# Altium schematic sheet WLED_Pixie_Rev_A_3_CPU.SchDoc(WLED_Pixie_Rev_A_3_CPU)
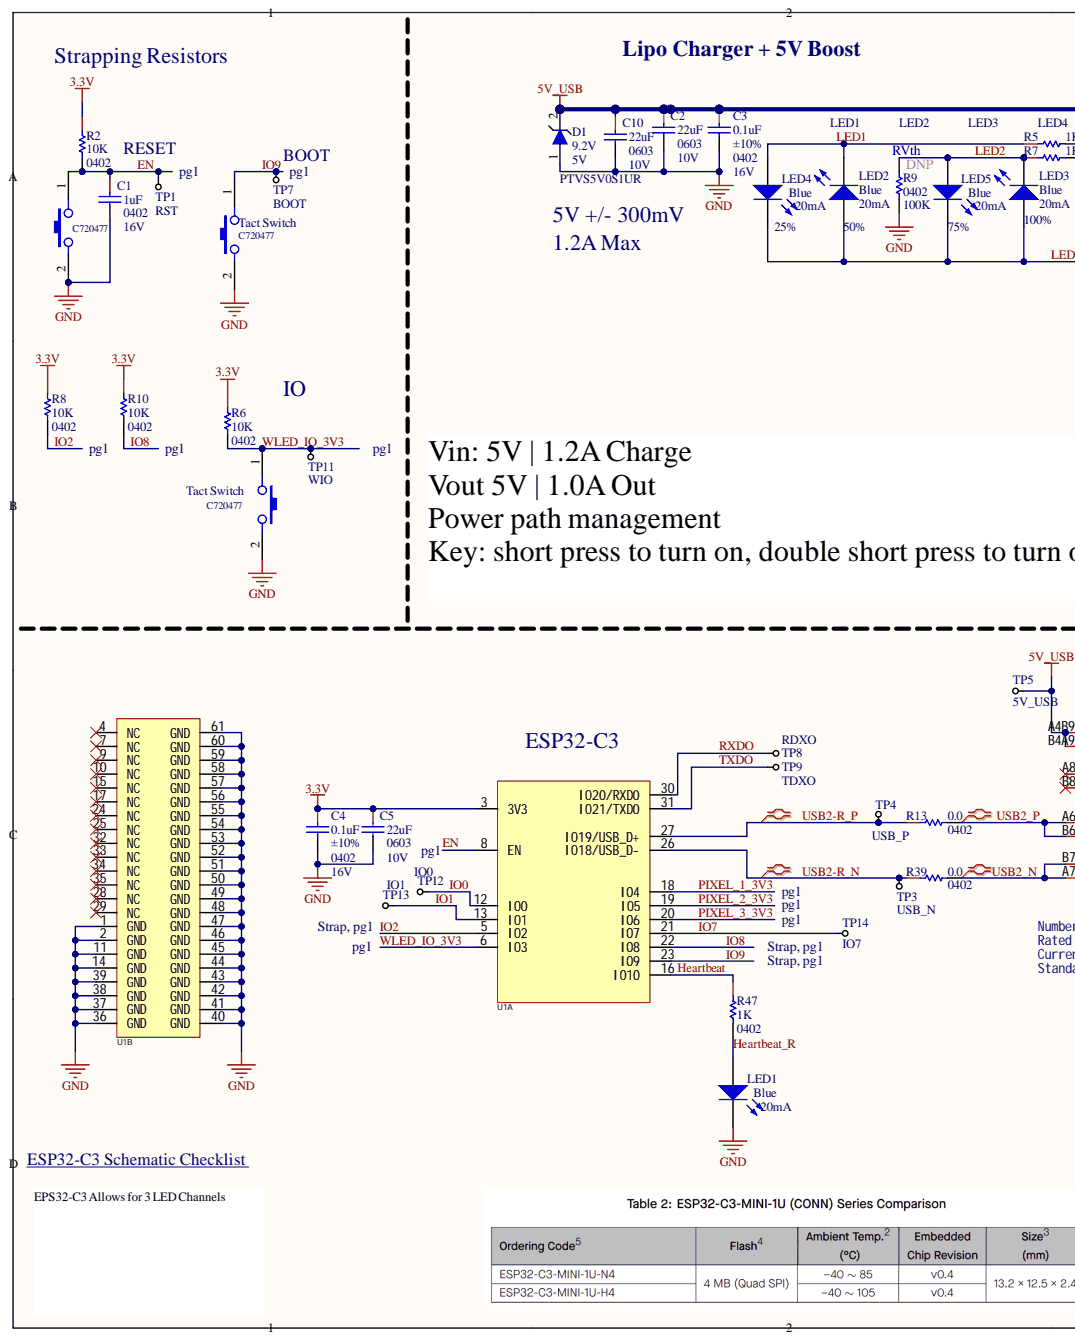
[diagram p0: WLED_Pixie_Rev_A_3_CPU.SchDoc, left]
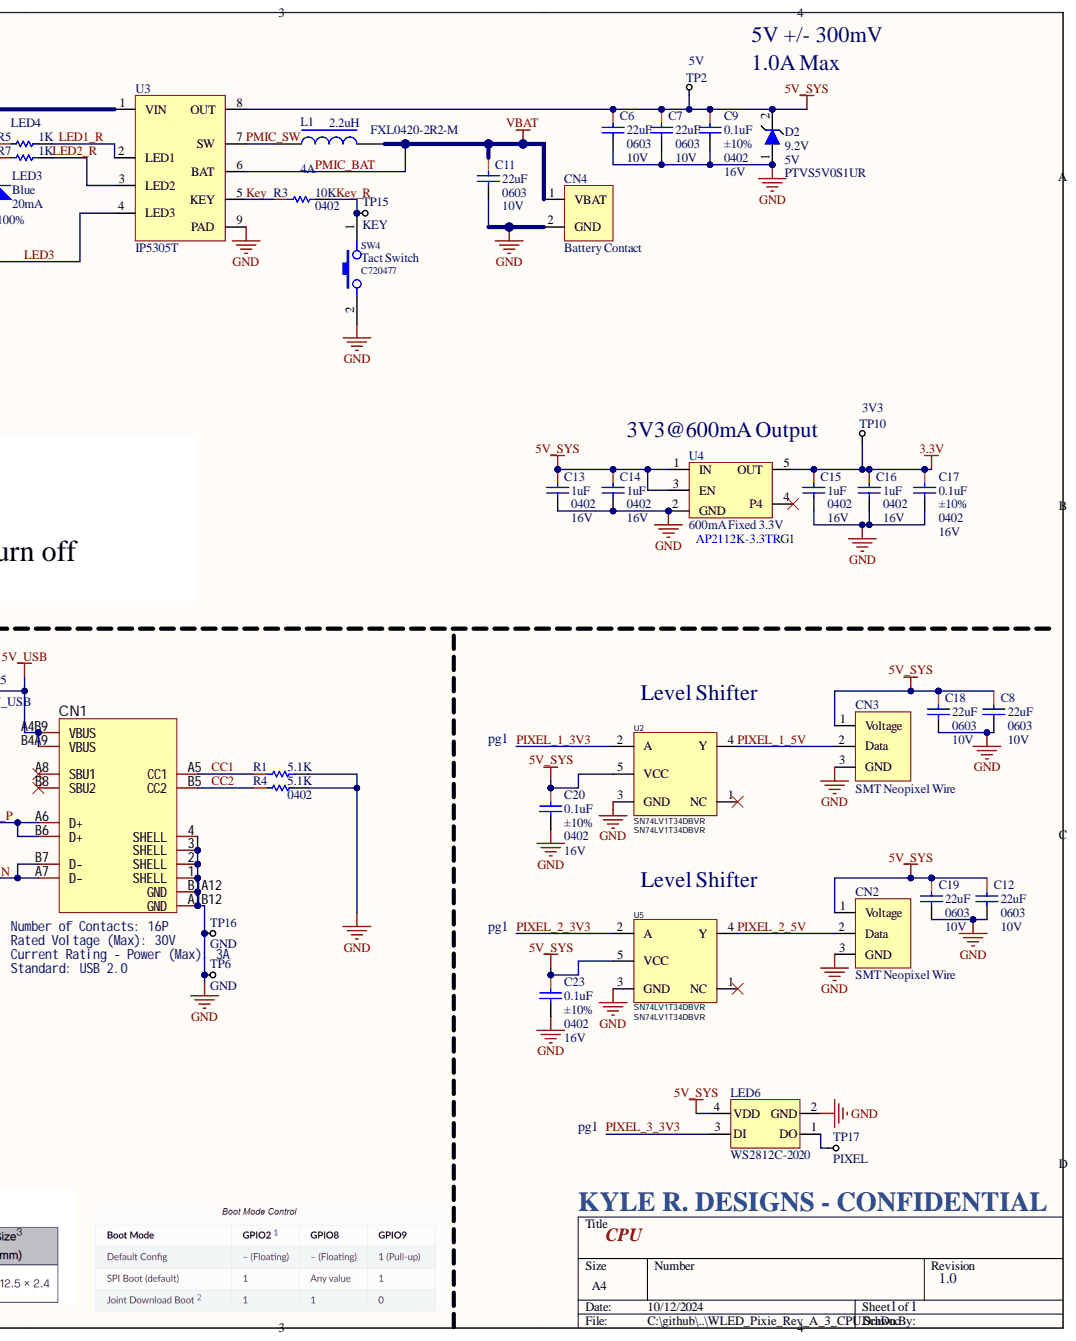
[diagram p1: WLED_Pixie_Rev_A_3_CPU.SchDoc, right]

## Parts
C1  1uF  Case Code (Imperial): 0402  Voltage - Rated: 16V  [CAPC0402(1005)_L]
C2  22uF  Package / Case: 0603  Voltage - Rated: 10V  [CAPC0603(1608)_L]
C3  0.1uF  Package / Case: 0402  Tolerance: ±10%  [CAPC0402(1005)_L]
C4  0.1uF  Package / Case: 0402  Tolerance: ±10%  Voltage - Rated: 16V  [CAPC0402(1005)_L]
C5  22uF  Package / Case: 0603  Voltage - Rated: 10V  [CAPC0603(1608)_L]
C6  22uF  Package / Case: 0603  Voltage - Rated: 10V  [CAPC0603(1608)_L]
C7  22uF  Package / Case: 0603  Voltage - Rated: 10V  [CAPC0603(1608)_L]
C8  22uF  Package / Case: 0603  Voltage - Rated: 10V  [CAPC0603(1608)_L]
C9  0.1uF  Package / Case: 0402  Tolerance: ±10%  Voltage - Rated: 16V  [CAPC0402(1005)_L]
C10  22uF  Package / Case: 0603  Voltage - Rated: 10V  [CAPC0603(1608)_L]
C11  22uF  Package / Case: 0603  Voltage - Rated: 10V  [CAPC0603(1608)_L]
C12  22uF  Package / Case: 0603  Voltage - Rated: 10V  [CAPC0603(1608)_L]
C13  1uF  Case Code (Imperial): 0402  Voltage - Rated: 16V  [CAPC0402(1005)_L]
C14  1uF  Case Code (Imperial): 0402  Voltage - Rated: 16V  [CAPC0402(1005)_L]
C15  1uF  Case Code (Imperial): 0402  Voltage - Rated: 16V  [CAPC0402(1005)_L]
C16  1uF  Case Code (Imperial): 0402  Voltage - Rated: 16V  [CAPC0402(1005)_L]
C17  0.1uF  Package / Case: 0402  Tolerance: ±10%  Voltage - Rated: 16V  [CAPC0402(1005)_L]
C18  22uF  Package / Case: 0603  Voltage - Rated: 10V  [CAPC0603(1608)_L]
C19  22uF  Package / Case: 0603  Voltage - Rated: 10V  [CAPC0603(1608)_L]
C20  0.1uF  Package / Case: 0402  Tolerance: ±10%  Voltage - Rated: 16V  [CAPC0402(1005)_L]
C23  0.1uF  Package / Case: 0402  Tolerance: ±10%  Voltage - Rated: 16V  [CAPC0402(1005)_L]
CN1  Number of Contacts: 16P  Rated Voltage (Max): 30V  [USB-C-SMD_TYPE-C16PIN]  (hidden comment: TYPE-C16PIN)
CN2  SMT Neopixel Wire  [SMT_Neopixel_Wire]
CN3  SMT Neopixel Wire  [SMT_Neopixel_Wire]
CN4  Battery Contact  [SMT_Battery]
D1  PTVS5V0S1UR  Max Clamp Voltage: 9.2V  Working Voltage: 5V  [SOD123]
D2  PTVS5V0S1UR  Max Clamp Voltage: 9.2V  Working Voltage: 5V  [SOD123]
L1  FXL0420-2R2-M  Inductance: 2.2uH  Isat MAX: 4A  [INDP4444X20N]
LED1  Blue  Current: 20mA  [LED_0402]
LED2  Blue  Current: 20mA  [LED_0402]
LED3  Blue  Current: 20mA  [LED_0402]
LED4  Blue  Current: 20mA  [LED_0402]
LED5  Blue  Current: 20mA  [LED_0402]
LED6  WS2812C-2020  [WS2812C-2020]
R1  5.1K  [RESC0402(1005)_L]
R2  10K  Supplier Device Package: 0402  [RESC0402(1005)_L]
R3  10K  Supplier Device Package: 0402  [RESC0402(1005)_L]
R4  5.1K  Supplier Device Package: 0402  [RESC0402(1005)_L]
R5  1K  [RESC0402(1005)_L]
R6  10K  Supplier Device Package: 0402  [RESC0402(1005)_L]
R7  1K  [RESC0402(1005)_L]
R8  10K  Supplier Device Package: 0402  [RESC0402(1005)_L]
R9  100K 0402  Case Code (Imperial): 0402  Resistance: 100K  [RESC0402(1005)_L]  (no BOM)
R10  10K  Supplier Device Package: 0402  [RESC0402(1005)_L]
R13  0.0  Package / Case: 0402  [RESC0402(1005)_L]
R39  0.0  Package / Case: 0402  [RESC0402(1005)_L]
R47  1K  Supplier Device Package: 0402  [RESC0402(1005)_L]
SW1  Tact Switch  LCSC Part #: C720477  [TS-1088-AR02016]
SW2  Tact Switch  LCSC Part #: C720477  [TS-1088-AR02016]
SW3  Tact Switch  LCSC Part #: C720477  [TS-1088-AR02016]
SW4  Tact Switch  LCSC Part #: C720477  [TS-1088-AR02016]
TP1  RST  [TestPoint-SM]  (no BOM)
TP2  5V  [TestPoint-SM]  (no BOM)
TP3  USB_N  [TestPoint-SM]  (no BOM)
TP4  USB_P  [TestPoint-SM]  (no BOM)
TP5  5V_USB  [TestPoint-SM]  (no BOM)
TP6  GND  [TestPoint-SM]  (no BOM)
TP7  BOOT  [TestPoint-SM]  (no BOM)
TP8  RDXO  [TestPoint-SM]  (no BOM)
TP9  TDXO  [TestPoint-SM]  (no BOM)
TP10  3V3  [TestPoint-SM]  (no BOM)
TP11  WIO  [TestPoint-SM]  (no BOM)
TP12  IO0  [TestPoint-SM]  (no BOM)
TP13  IO1  [TestPoint-SM]  (no BOM)
TP14  IO7  [TestPoint-SM]  (no BOM)
TP15  KEY  [TestPoint-SM]  (no BOM)
TP16  GND  [TestPoint-SM]  (no BOM)
TP17  PIXEL  [TestPoint-SM]  (no BOM)
U1  Espressif Systems'  [WIFIM-SMD_61P-L13.2-W12.5-P0.80]  (value not drawn)  (parts A,B)
U2  SN74LV1T34DBVR  [SOT23-5]
U3  IP5305T  [SOP127P600X175_HS-9N_no_vias]
U4  600mA Fixed 3.3V  [SOT95P285X130-5N]
U5  SN74LV1T34DBVR  [SOT23-5]

## Nets
3.3V: C4.1 C5.1 C15.1 C16.1 C17.1 R2.1 R6.1 R8.1 R10.1 TP10.1 U1.3(3V3) U4.5(OUT)
5V_SYS: C6.1 C7.1 C8.1 C9.1 C12.1 C13.1 C14.1 C18.1 C19.1 C20.1 C23.1 CN2.1 CN3.1 D2.2 LED6.4(VDD) TP2.1 U2.5(VCC) U3.8(OUT) U4.1(IN) U4.3(EN) U5.5(VCC)
5V_USB: C2.1 C3.1 C10.1 CN1.A4B9(VBUS) CN1.B4A9(VBUS) D1.2 TP5.1 U3.1(VIN)
GND: C1.2 C2.2 C3.2 C4.2 C5.2 C6.2 C7.2 C8.2 C9.2 C10.2 C11.2 C12.2 C13.2 C14.2 C15.2 C16.2 C17.2 C18.2 C19.2 C20.2 C23.2 CN1.1(SHELL) CN1.2(SHELL) CN1.3(SHELL) CN1.4(SHELL) CN1.A1B12(GND) CN1.B1A12(GND) CN2.3 CN3.3 CN4.2 D1.1 D2.1 LED1.2 LED6.2(GND) R1.2 R4.2 R9.1 SW1.2 SW2.2 SW3.2 SW4.2 TP6.1 TP16.1 U1.1(GND) U1.2(GND) U1.11(GND) U1.14(GND) U1.36(GND) U1.37(GND) U1.38(GND) U1.39(GND) U1.40(GND) U1.41(GND) U1.42(GND) U1.43(GND) U1.44(GND) U1.45(GND) U1.46(GND) U1.47(GND) U1.48(GND) U1.49(GND) U1.50(GND) U1.51(GND) U1.52(GND) U1.53(GND) U1.54(GND) U1.55(GND) U1.56(GND) U1.57(GND) U1.58(GND) U1.59(GND) U1.60(GND) U1.61(GND) U2.3(GND) U3.9(PAD) U4.2(GND) U5.3(GND)
CC1: CN1.A5(CC1) R1.1
CC2: CN1.B5(CC2) R4.1
EN: C1.1 R2.2 SW3.1 TP1.1 U1.8(EN)
Heartbeat: R47.1 U1.16(IO10)
Heartbeat_R: LED1.1 R47.2
IO0: TP12.1 U1.12(IO0)
IO1: TP13.1 U1.13(IO1)
IO2: R8.2 U1.5(IO2)
IO7: TP14.1 U1.21(IO7)
IO8: R10.2 U1.22(IO8)
IO9: SW1.1 TP7.1 U1.23(IO9)
Key: R3.1 U3.5(KEY)
Key_R: R3.2 SW4.1 TP15.1
LED1: LED2.2 LED4.1 R5.1
LED1_R: R5.2 U3.2(LED1)
LED2: LED3.2 LED5.1 R7.1 R9.2
LED2_R: R7.2 U3.3(LED2)
LED3: LED2.1 LED3.1 LED4.2 LED5.2 U3.4(LED3)
PIXEL_1_3V3: U1.18(IO4) U2.2(A)
PIXEL_1_5V: CN3.2 U2.4(Y)
PIXEL_2_3V3: U1.19(IO5) U5.2(A)
PIXEL_2_5V: CN2.2 U5.4(Y)
PIXEL_3_3V3: LED6.3(DI) U1.20(IO6)
PMIC_BAT: C11.1 CN4.1 L1.2 U3.6(BAT)
PMIC_SW: L1.1 U3.7(SW)
RXDO: TP8.1 U1.30(IO20/RXD0)
TXDO: TP9.1 U1.31(IO21/TXD0)
USB2-R_N: R39.1 TP3.1 U1.26(IO18/USB_D-)
USB2-R_P: R13.1 TP4.1 U1.27(IO19/USB_D+)
USB2_N: CN1.A7(D-) CN1.B7(D-) R39.2
USB2_P: CN1.A6(D+) CN1.B6(D+) R13.2
WLED_IO_3V3: R6.2 SW2.1 TP11.1 U1.6(IO3)
NetLED6_1: LED6.1(DO) TP17.1

Not connected: CN1 A8(SBU1) B8(SBU2); U1 4(NC) 7(NC) 9(NC) 10(NC) 15(NC) 17(NC) 24(NC) 25(NC) 28(NC) 29(NC) 32(NC) 33(NC) 34(NC) 35(NC); U2 1(NC); U4 4(P4); U5 1(NC)

## Library data of the parts
C1 C13-C16 = CAP CER 1UF 16V 10% X5R 0402: 1UF 0402; Manufacturer: TDK; Manufacturer Part Number: CGB2A1X5R1C105K033BC; Dielectric: X5R; Notification: Current market demand for these product types have resulted in fluctuating and extending lead times. Lead times may differ.; Temperature Coefficient: JB; Tolerance: 10%; Applications: General Purpose; Capacitance: 1uF; Case Code (Metric): 1005; Case/Package: 0402; Category: Capacitors; Component Kind: Standard; ComponentLink1Description: http://product.tdk.com/en/catalog/datasheets/mlcc_commercial_general_en.pdf; ComponentLink1URL: http://product.tdk.com/en/catalog/datasheets/mlcc_commercial_general_en.pdf; ComponentLink2Description: https://product.tdk.com/info/en/catalog/datasheets/mlcc_commercial_lowprofile_en.pdf; ComponentLink2URL: https://product.tdk.com/info/en/catalog/datasheets/mlcc_commercial_lowprofile_en.pdf; ComponentLink3Description: http://product.tdk.com/en/catalog/datasheets/mlcc_commercial_lowprofile_en.pdf; ComponentLink3URL: http://product.tdk.com/en/catalog/datasheets/mlcc_commercial_lowprofile_en.pdf; ComponentLink4Description: http://www.mouser.com/ds/2/400/lcc_commercial_lowprofile_en-520027.pdf; ComponentLink4URL: http://www.mouser.com/ds/2/400/lcc_commercial_lowprofile_en-520027.pdf; Composition: Ceramic; Failure Rate: -; Features: Low Profile; Height: 0.33mm; Height - Seated (Max): -; Lead Spacing: -; Lead Style: -; Length: 0.039inch; Max Operating Temperature: 85°C; Min Operating Temperature: -55°C; Mount: Surface Mount; Mounting Type: Surface Mount, MLCC; Operating Temperature: -25°C ~ 85°C; Package / Case: 0402; Package Quantity: 10000; Packaging: Tape and Reel; Part Status: Active; Pricing 1: 1=0.19, 10=0.133, 100=0.0673, 500=0.04604, 1000=0.04073, 2500=0.03896, 5000=0.03542 (USD); Pricing 2: 1=0.22, 10=0.151, 100=0.0765, 500=0.05232, 1000=0.04629, 2500=0.04428, 5000=0.04025 (USD); Ratings: -; RoHS: RoHS Compliant; Size / Dimension: 0.039" L x 0.020" W (1.00mm x 0.50mm); Stock 1: 0; Stock 2: 6711; Supplier 1: Digi-Key; Supplier 2: Digi-Key; Supplier Part Number 1: 445-13181-1-ND; Supplier Part Number 2: 445-12012-1-ND; Termination: SMD/SMT; Thickness: 0.013inch; Thickness (Max): 0.013" (0.33mm); Voltage Rating: 16V; Voltage Rating (DC): 16V; Width: 0.5mm; Library Name: Kyle-Passive.IntLib
C2 C5-C8 C10-C12 C18 C19 = CAP CER 22UF 10V X5R 0603: 22uF 0603 10V; Manufacturer: Murata Electronics; Manufacturer Part Number: GRM188R61A226ME15D; Capacitance: 22µF; Temperature Coefficient: XR5; Tolerance: ±20%; Applications: General Purpose; Category: Capacitors; Component Kind: Standard; Features: -; Height - Seated (Max): -; Lead Spacing: -; Lead Style: -; Library Name: JLCPCB_Passive.IntLib; Mounting Type: Surface Mount, MLCC; Operating Temperature: -55°C ~ 125°C; Packaging: Tape & Reel (TR); Pricing 1: 4000=0.00414, 8000=0.00378, 12000=0.0036, 28000=0.00342, 100000=0.00248 (USD); Ratings: -; RoHS: RoHS Compliant; Size / Dimension: 0.063" L x 0.031" W (1.60mm x 0.80mm); Stock 1: 2360000; Supplier 1: LCSC; Supplier Part Number 1: C84419; Thickness (Max): 0.034" (0.87mm)
C3 C4 C9 C17 C20 C23 = CAP CER 0.1UF 16V 10% X7R 0402: 0.1uF 0402 16V; Manufacturer: American Technical Ceramics; Manufacturer Part Number: 530L104KT16T; Notification: Current market demand for these product types have resulted in fluctuating and extending lead times. Lead times may differ.; Temperature Coefficient: X7R; Applications: SMPS Filtering; Category: Capacitors; Component Kind: Standard; ComponentLink1Description: https://www.atceramics.com/UserFiles/530l.pdf; ComponentLink1URL: https://www.atceramics.com/UserFiles/530l.pdf; Failure Rate: -; Features: -; Height - Seated (Max): -; Lead Spacing: -; Lead Style: -; Mounting Type: Surface Mount, MLCC; Operating Temperature: -55°C ~ 125°C; Packaging: Cut Tape (CT); Part Status: Active; Pricing 1: 1=1.23, 10=0.975, 100=0.731 (USD); Ratings: -; RoHS: RoHS Compliant; Size / Dimension: 0.040" L x 0.020" W (1.02mm x 0.51mm); Stock 1: 201522; Supplier 1: Digi-Key; Supplier Part Number 1: 1284-1591-1-ND; Thickness (Max): 0.024" (0.61mm); Library Name: Kyle-Passive.IntLib
  C3: Voltage - Rated: 16V
CN1 = TYPE-C16PIN: USB Type-C 2.0 3A 30V; Manufacturer: SHOU HAN(首韩); Add into BOM: yes; Connect-Disconnect Life: 10,000 times; Core Colour: Black; Gender: Female; Number of Ports: 1; Component Kind: Standard; Connector Type: Type-C; Convert to PCB: yes; Current Rating - Power (Max): 3A; Datasheet: https://atta.szlcsc.com/upload/public/pdf/source/20190521/C393939_7B9043D4CF1026AF443AB60D91FBCD5C.pdf; Device: TYPE-C16PIN; JLCPCB Part Class: Extended Part; LCSC Part Name: Type-C 母 卧贴; Manufacturer Part: TYPE-C16PIN; Mounting Style: Horizontal attachment; Operating Temperature Range: -40℃~+85℃; Standard: USB 2.0; Supplier: LCSC; Supplier Footprint: SMD; Supplier Part: C393939; Symbol: TYPE-C16PIN; Library Name: Kyle-Connectors.IntLib
CN2 CN3 = Neopixel_SMT: Component Kind: Standard; Library Name: Kyle-Misc.IntLib
CN4 = Battery_SMT_Contact: Component Kind: Standard; Library Name: Kyle-Misc.IntLib
D1 D2 = TVS 5V 400W: 43.6A 9.2V 400W 6.4V Unidirectional 5V; Manufacturer: FUXINSEMI; Max Current: 43A; Component Kind: Standard; ComponentLink1Description: Datasheet; ComponentLink1URL: https://wmsc.lcsc.com/wmsc/upload/file/pdf/v2/lcsc/2401151102_FUXINSEMI-PTVS24VS1UR_C5380693.pdf; MFR.Part #: PTVS5V0S1UR; Supplier 1: Future Electronics; Supplier Part Number 1: 8850067; Library Name: Kyle-Diode-Opto-LED.IntLib
L1 = IND 22UH 4A SMD,4.2x4.4mm: 2.2uH 4A SMD,4.2x4.4mm; Manufacturer: cjiang (Changjiang Microelectronics Tech); DCR: 58; Irms MAX: 3.8; Component Kind: Standard; ComponentLink1Description: Datasheet; ComponentLink1URL: https://www.lcsc.com/datasheet/lcsc_datasheet_2404260921_cjiang--Changjiang-Microelectronics-Tech-FXL0420-2R2-M_C167206.pdf; LCSC Part #: C167206; Library Name: JLCPCB_Passive.IntLib; Mfr. Part #: FXL0420-2R2-M
LED1-LED5 = XL-1005UBC: Blue 0402 3.3V; Voltage: 2.7V to 3.3V; Color: Blue; Component Kind: Standard; ComponentLink1Description: Datasheet; ComponentLink1URL: https://wmsc.lcsc.com/wmsc/upload/file/pdf/v2/lcsc/2403110921_XINGLIGHT-XL-1005UBC_C22355736.pdf; Supplier 1: LCSC; Supplier Part Number 1: C22355736; Library Name: Kyle-Diode-Opto-LED.IntLib
LED6 = WS2812C-2020: Neopixel; Component Kind: Standard; Library Name: Kyle-Diode-Opto-LED.IntLib
R1 R4 = RES 5.1K OHM 1% 1/16W 0402: 5.1K 0402; Manufacturer: UNI-ROYAL(Uniroyal Elec); Manufacturer Part Number: 0402WGF5101TCE; Power (Watts): 0.063W, 1/16W; Temperature Coefficient: ±100ppm/°C; Tolerance: ±1%; Category: Resistors; Component Kind: Standard; Composition: Thick Film; Failure Rate: -; Features: Moisture Resistant; Height - Seated (Max): 0.016" (0.40mm); JLCPCB Part #: C25905; Library Name: JLCPCB_Passive.IntLib; Operating Temperature: -55°C ~ 155°C; Package / Case: 0402 (1005 Metric); Packaging: Cut Tape (CT); Part Status: Active; Pricing 1: 1=0.1, 10=0.021, 100=0.0086, 1000=0.00385, 2500=0.00334, 5000=0.00276 (USD); Resistance (Ohms): 5.1K; RoHS: RoHS Compliant; Size / Dimension: 0.039" L x 0.020" W (1.00mm x 0.50mm); Supplier 1: LCSC; Supplier Part Number 1: C25905
  R1: Supplier Device Package: 0402
R2 R3 R6 R8 R10 = RES 10K OHM 1% 1/16W 0402: 10K 0402; Manufacturer: UNI-ROYAL(Uniroyal Elec); Manufacturer Part Number: 0402WGF1002TCE; Power (Watts): 0.063W, 1/16W; Temperature Coefficient: ±100ppm/°C; Tolerance: ±1%; Category: Resistors; Component Kind: Standard; Composition: Thick Film; Failure Rate: -; Features: Moisture Resistant; Height - Seated (Max): 0.016" (0.40mm); JLCPCB Part #: C25744; Library Name: JLCPCB_Passive.IntLib; Operating Temperature: -55°C ~ 155°C; Package / Case: 0402 (1005 Metric); Packaging: Cut Tape (CT); Part Status: Active; Pricing 1: 1=0.1, 10=0.021, 100=0.0086, 1000=0.00385, 2500=0.00334, 5000=0.00276 (USD); Resistance (Ohms): 10K; RoHS: RoHS Compliant; Size / Dimension: 0.039" L x 0.020" W (1.00mm x 0.50mm)
R5 R7 R47 = RES 1K OHM 1% 1/16W 0402: 1K 0402; Manufacturer: UNI-ROYAL(Uniroyal Elec); Manufacturer Part Number: 0402WGF1001TCE; Power (Watts): 0.063W, 1/16W; Temperature Coefficient: ±100ppm/°C; Tolerance: ±1%; Category: Resistors; Component Kind: Standard; Composition: Thick Film; Failure Rate: -; Features: Moisture Resistant; Height - Seated (Max): 0.016" (0.40mm); JLCPCB Part #: C11702; Library Name: JLCPCB_Passive.IntLib; Operating Temperature: -55°C ~ 155°C; Package / Case: 0402 (1005 Metric); Packaging: Cut Tape (CT); Part Status: Active; Pricing 1: 1=0.1, 10=0.021, 100=0.0086, 1000=0.00385, 2500=0.00334, 5000=0.00276 (USD); Resistance (Ohms): 1K; RoHS: RoHS Compliant; Size / Dimension: 0.039" L x 0.020" W (1.00mm x 0.50mm)
  R5 R7: Supplier Device Package: 0402
R9 = RES 100K OHM 1% 1/16W 0402: Manufacturer: Yageo; Manufacturer Part Number: RC0402FR-07100KL; Max Power Dissipation: 63mW; Temperature Coefficient: 100ppm/°C; Tolerance: ±1%; Voltage Rating: 50V; Case Code (Metric): 1005; Case/Package: 0402; Category: Resistors; ComponentLink1Description: http://industrial.panasonic.com/www-cgi/jvcr13pz.cgi?E+PZ+3+AOA0002+ERJ2RKF1003X+7+WW; ComponentLink1URL: http://industrial.panasonic.com/www-cgi/jvcr13pz.cgi?E+PZ+3+AOA0002+ERJ2RKF1003X+7+WW; ComponentLink2Description: https://datasheet.ciiva.com/2453/pyu-r-int-thick-7-2453361.pdf; ComponentLink2URL: https://datasheet.ciiva.com/2453/pyu-r-int-thick-7-2453361.pdf; ComponentLink3Description: https://datasheet.ciiva.com/2453/pyu-r-int-thick-7-2453362.pdf; ComponentLink3URL: https://datasheet.ciiva.com/2453/pyu-r-int-thick-7-2453362.pdf; ComponentLink4Description: https://datasheet.ciiva.com/2453/pyu-r-int-thick-7-2453363.pdf; ComponentLink4URL: https://datasheet.ciiva.com/2453/pyu-r-int-thick-7-2453363.pdf; ComponentLink5Description: https://datasheet.ciiva.com/22747/1018594-22747509.pdf; ComponentLink5URL: https://datasheet.ciiva.com/22747/1018594-22747509.pdf; ComponentLink6Description: https://datasheet.ciiva.com/15189/getdatasheetpartid-323696-15189334.pdf; ComponentLink6URL: https://datasheet.ciiva.com/15189/getdatasheetpartid-323696-15189334.pdf; ComponentLink7Description: https://datasheet.ciiva.com/16740/pyu-rc0402-51-rohs-l-6-r-16740567.pdf; ComponentLink7URL: https://datasheet.ciiva.com/16740/pyu-rc0402-51-rohs-l-6-r-16740567.pdf; ComponentLink8Description: https://datasheet.ciiva.com/12667/pyu-rc0402-51-rohs-l-6-r-12667930.pdf; ComponentLink8URL: https://datasheet.ciiva.com/12667/pyu-rc0402-51-rohs-l-6-r-12667930.pdf; ComponentLink9Description: https://datasheet.ciiva.com/11819/0900766b80c66297-11819452.pdf; ComponentLink9URL: https://datasheet.ciiva.com/11819/0900766b80c66297-11819452.pdf; Composition: Thick Film; Depth: 0.5mm; Description: 100K 0402; Height: 0.016inch; Lead Free: Lead Free; Length: 0.039inch; Max Operating Temperature: 155°C; Military Standard: Not; Min Operating Temperature: -55°C; Number of Terminations: 2; Package / Case: 0402; Package Quantity: 1; Packaging: Tape and Reel; Power Rating: 63mW; Pricing 1: 1=0.1, 10=0.014, 100=0.0056, 1000=0.0025, 2500=0.0022, 5000=0.0018 (USD); REACH SVHC: No SVHC; Resistance (Ohms): 100K; Stock 1: 18204796; Supplier 1: Digi-Key; Supplier Part Number 1: 311-100KLRCT-ND; Termination: SMD/SMT; Width: 0.02inch; Library Name: Kyle-Passive.IntLib
R13 R39 = RES 0.0 OHM JUMPER 1/10W 0402: 0.0 0402; Manufacturer: UNI-ROYAL(Uniroyal Elec); Manufacturer Part Number: 0402WGF0000TCE; Power (Watts): 0.1W, 1/10W; Tolerance: Jumper; Category: Resistors; Component Kind: Standard; Composition: Thick Film; Features: Automotive AEC-Q200; Height: 0.016" (0.40mm); JLCPCB Part #: C17168; Library Name: JLCPCB_Passive.IntLib; Number of Terminations: 2; Operating Temperature: -55°C ~ 155°C; Packaging: Cut Tape (CT); Pricing 1: 1=0.1, 50=0.0138, 100=0.0105, 250=0.0078, 500=0.00576, 1000=0.00384, 5000=0.0027 (USD); Resistance (Ohms): 0.0; RoHS: RoHS Compliant; Size / Dimension: 0.039" L x 0.020" W (1.00mm x 0.50mm); Supplier Device Package: 0402; Temperature Coefficient: -
SW1-SW4 = TS-1088-AR02016: SMD,3x4x2mm Tactile Switches ROHS; Manufacturer: XUNPU; Component Kind: Standard; Library Name: JLCPCB_Switch.SchLib
TP1-TP17 = TestPoint, small: Test Point; Library Name: Kyle-Misc.IntLib
U1 = ESP32-C3-MINI-1U-N4: Add into BOM: yes; Component Kind: Standard; Convert to PCB: yes; Device: ESP32-C3-MINI-1U-N4; JLCPCB Part #: C2911374; Manufacturer: Espressif Systems; Package: SMD,12.5x13.2mm; Symbol: ESP32-C3-MINI-1U-N4; Library Name: Kyle-CPU-Memory.SCHLIB
U2 U5 = SN74LV1T34DBVR: Level Shifter - single output; Manufacturer: TI(德州仪器); Add into BOM: yes; Logic Family: 74LV; Number of Bits per Element: 1; output type: 推挽; Sink Current: 8mA; Supply Voltage: 1.6V~5.5V; Component Kind: Standard; Convert to PCB: yes; Datasheet: https://www.ti.com/cn/lit/gpn/sn74lv1t34; Device: SN74LV1T34DBVR; Input type: -; JLCPCB Part Class: Extended Part; LCSC Part Name: SN74LV1T34DBVR; Manufacturer Part: SN74LV1T34DBVR; Number of Elements: 1; Operating Temperature: -40℃~+125℃; Source Current: 8mA; Supplier: LCSC; Supplier Footprint: SOT-23-5; Supplier Part: C100024; Symbol: SN74LV1T34DBVR; Library Name: Kyle-Logic.IntLib
U3 = IP5305T: 1.2A Charge, 1.0 A Discharge  Mobile Power Supply SOC; Manufacturer: Injoin Technology; Mfr. Part #: IP5305T-BT; Component Kind: Standard; LCSC Part No.: C7433865; Library Name: Kyle-Reg-Convert.IntLib
U4 = AP2112K-3.3TRG1: 65dB@(100Hz,1kHz) 600mA Fixed 3.3V~3.3V Positive 6V SOT-25-5 Linear Voltage Regulators (LDO) ROHS; Component Kind: Standard; MFR.Part #: AP2112K-3.3TRG1; Package: SOT-25-5; Supplier 1: LCSC; Supplier Part Number 1: C51118; Library Name: Kyle-Reg-Convert.IntLib
